# Altium schematic sheet main.SchDoc(main)
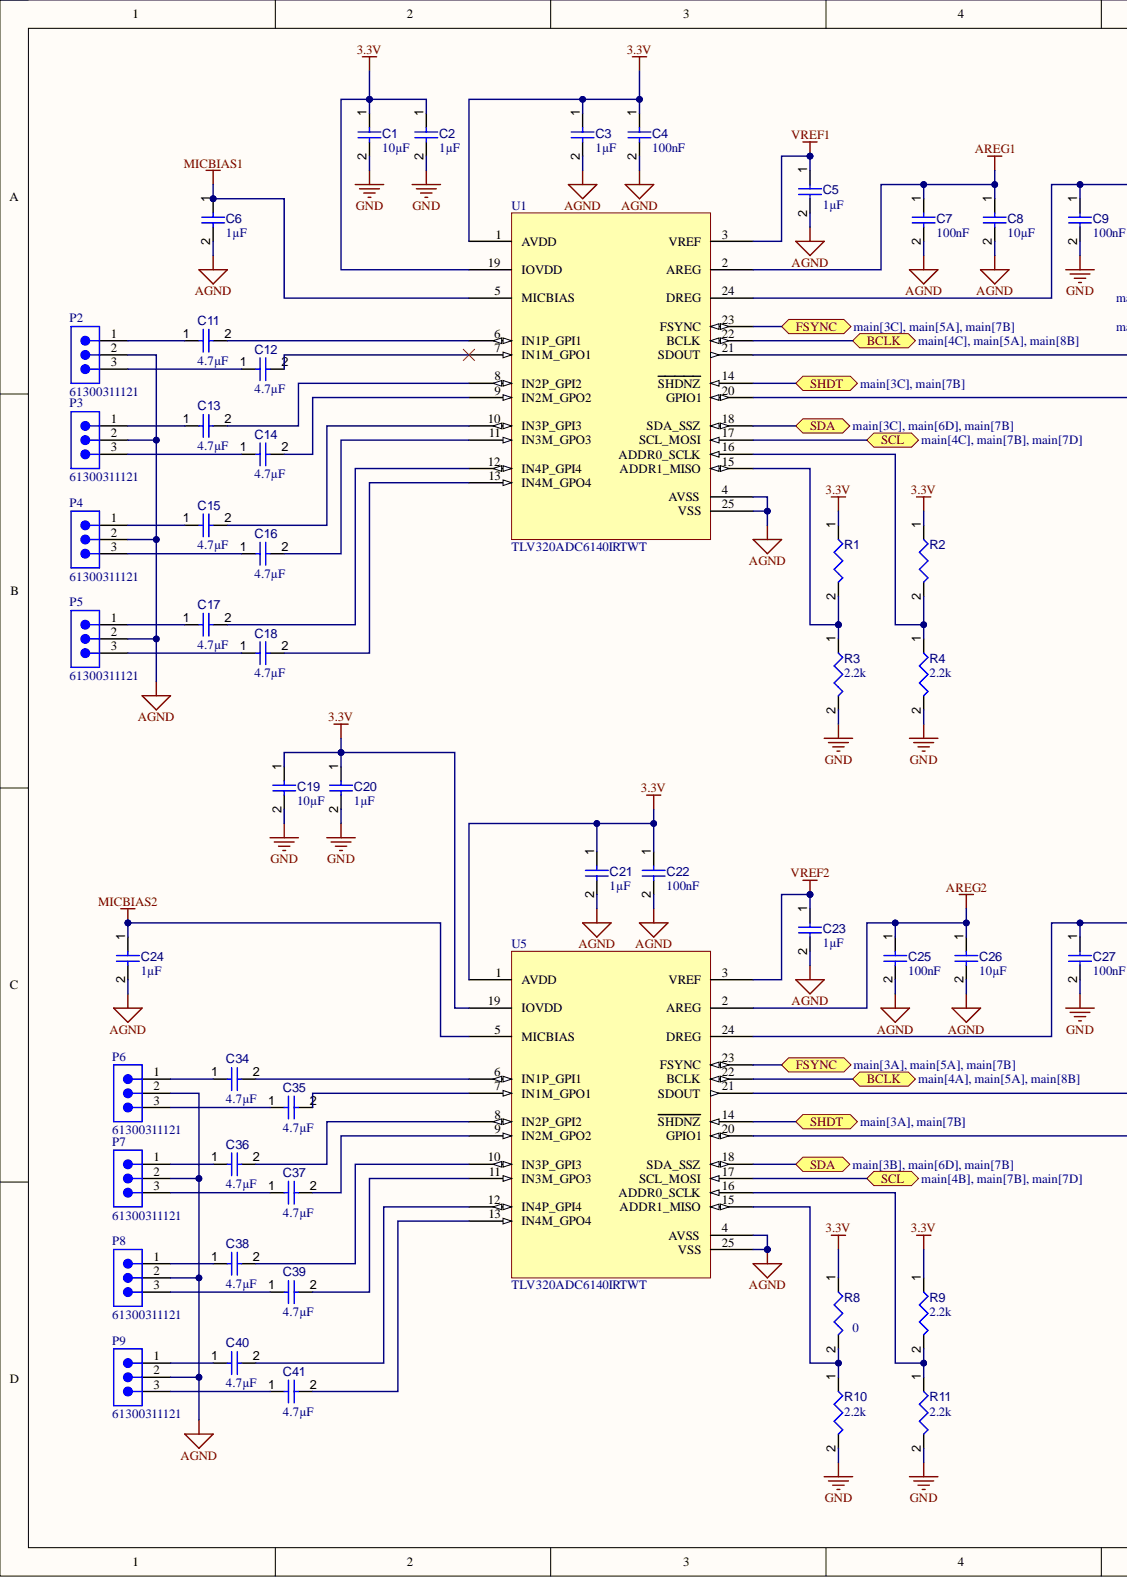
[diagram p0: main.SchDoc, left]
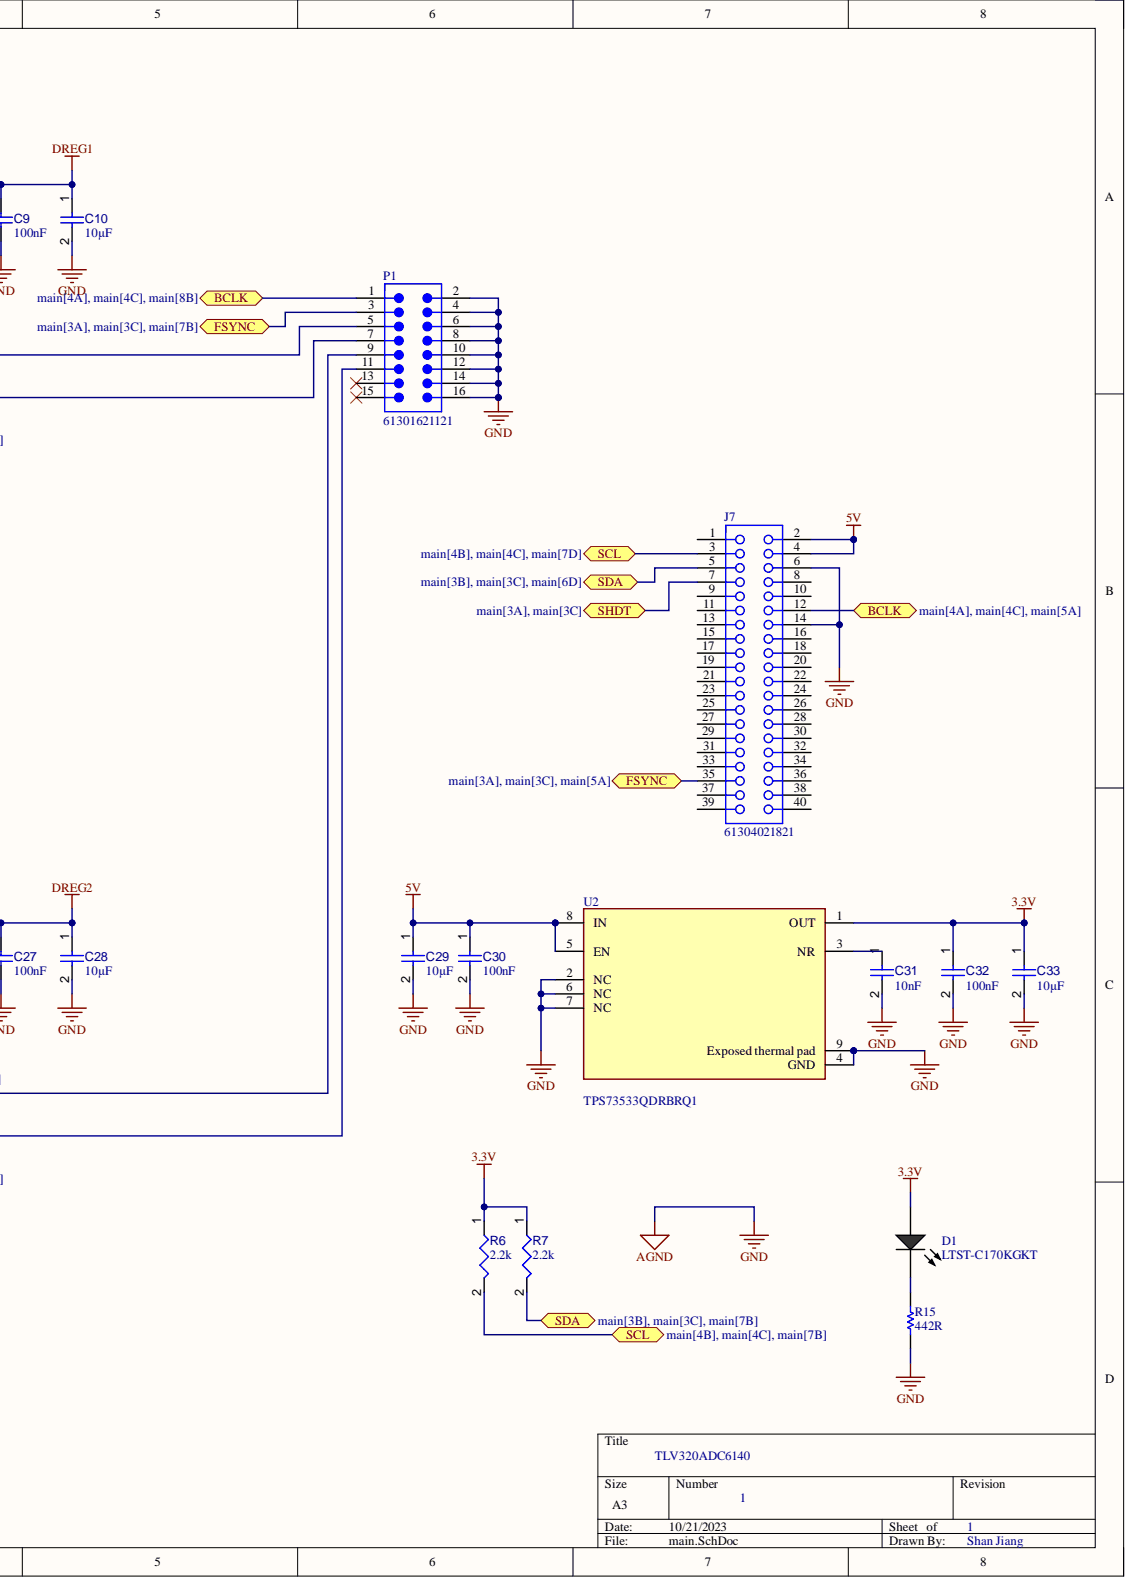
[diagram p1: main.SchDoc, right]

## Parts
C1  value 10µF  (hidden comment: GRM188R61A106ME69D)
C2  value 1µF  (hidden comment: GCM188R71C105KA64J)
C3  value 1µF  (hidden comment: GCM188R71C105KA64J)
C4  value 100nF  (hidden comment: GCM188R71C104KA37D)
C5  value 1µF  (hidden comment: GCM188R71C105KA64J)
C6  value 1µF  (hidden comment: GCM188R71C105KA64J)
C7  value 100nF  (hidden comment: GCM188R71C104KA37D)
C8  value 10µF  (hidden comment: GRM188R61A106ME69D)
C9  value 100nF  (hidden comment: GCM188R71C104KA37D)
C10  value 10µF  (hidden comment: GRM188R61A106ME69D)
C11  value 4.7µF  (hidden comment: GRM188C71A475KE11D)
C12  value 4.7µF  (hidden comment: GRM188C71A475KE11D)
C13  value 4.7µF  (hidden comment: GRM188C71A475KE11D)
C14  value 4.7µF  (hidden comment: GRM188C71A475KE11D)
C15  value 4.7µF  (hidden comment: GRM188C71A475KE11D)
C16  value 4.7µF  (hidden comment: GRM188C71A475KE11D)
C17  value 4.7µF  (hidden comment: GRM188C71A475KE11D)
C18  value 4.7µF  (hidden comment: GRM188C71A475KE11D)
C19  value 10µF  (hidden comment: GRM188R61A106ME69D)
C20  value 1µF  (hidden comment: GCM188R71C105KA64J)
C21  value 1µF  (hidden comment: GCM188R71C105KA64J)
C22  value 100nF  (hidden comment: GCM188R71C104KA37D)
C23  value 1µF  (hidden comment: GCM188R71C105KA64J)
C24  value 1µF  (hidden comment: GCM188R71C105KA64J)
C25  value 100nF  (hidden comment: GCM188R71C104KA37D)
C26  value 10µF  (hidden comment: GRM188R61A106ME69D)
C27  value 100nF  (hidden comment: GCM188R71C104KA37D)
C28  value 10µF  (hidden comment: GRM188R61A106ME69D)
C29  value 10µF  (hidden comment: GRM188R61A106ME69D)
C30  value 100nF  (hidden comment: GCM188R71C104KA37D)
C31  value 10nF  (hidden comment: GCM188R72A103KA37D)
C32  value 100nF  (hidden comment: GCM188R71C104KA37D)
C33  value 10µF  (hidden comment: GRM188R61A106ME69D)
C34  value 4.7µF  (hidden comment: GRM188C71A475KE11D)
C35  value 4.7µF  (hidden comment: GRM188C71A475KE11D)
C36  value 4.7µF  (hidden comment: GRM188C71A475KE11D)
C37  value 4.7µF  (hidden comment: GRM188C71A475KE11D)
C38  value 4.7µF  (hidden comment: GRM188C71A475KE11D)
C39  value 4.7µF  (hidden comment: GRM188C71A475KE11D)
C40  value 4.7µF  (hidden comment: GRM188C71A475KE11D)
C41  value 4.7µF  (hidden comment: GRM188C71A475KE11D)
D1  LTST-C170KGKT
J7  61304021821
P1  61301621121
P2  61300311121
P3  61300311121
P4  61300311121
P5  61300311121
P6  61300311121
P7  61300311121
P8  61300311121
P9  61300311121
R1  CRCW0603-0000Z0EA  (value not drawn)
R2  CRCW0603-0000Z0EA  (value not drawn)
R3  value 2.2k  (hidden comment: CRCW06032K20FKEA)
R4  value 2.2k  (hidden comment: CRCW06032K20FKEA)
R6  value 2.2k  (hidden comment: CRCW06032K20FKEA)
R7  value 2.2k  (hidden comment: CRCW06032K20FKEA)
R8  value 0  (hidden comment: CRCW0603-0000Z0EA)
R9  value 2.2k  (hidden comment: CRCW06032K20FKEA)
R10  value 2.2k  (hidden comment: CRCW06032K20FKEA)
R11  value 2.2k  (hidden comment: CRCW06032K20FKEA)
R15  value 442R  (hidden comment: CRCW0603442RFKEA)
U1  TLV320ADC6140IRTWT
U2  TPS73533QDRBRQ1
U5  TLV320ADC6140IRTWT

## Ports
BCLK, FSYNC, SCL, SDA, SHDT

## Nets
3.3V: C1.1 C2.1 C3.1 C4.1 C19.1 C20.1 C21.1 C22.1 C32.1 C33.1 D1.2 R1.1 R2.1 R6.1 R7.1 R8.1 R9.1 U1.1(AVDD) U1.19(IOVDD) U2.1(OUT) U5.1(AVDD) U5.19(IOVDD)
5V: C29.1 C30.1 J7.2 J7.4 U2.5(EN) U2.8(IN)
AGND: C1.2 C2.2 C3.2 C4.2 C5.2 C6.2 C7.2 C8.2 C9.2 C10.2 C19.2 C20.2 C21.2 C22.2 C23.2 C24.2 C25.2 C26.2 C27.2 C28.2 C29.2 C30.2 C31.2 C32.2 C33.2 J7.6 J7.14 P1.2 P1.4 P1.6 P1.8 P1.10 P1.12 P1.14 P1.16 P2.2 P3.2 P4.2 P5.2 P6.2 P7.2 P8.2 P9.2 R3.2 R4.2 R10.2 R11.2 R15.1 U1.4(AVSS) U1.25(VSS) U2.2(NC) U2.4(GND) U2.6(NC) U2.7(NC) U2.9(Exposed_thermal_pad) U5.4(AVSS) U5.25(VSS)
AREG1: C7.1 C8.1 U1.2(AREG)
AREG2: C25.1 C26.1 U5.2(AREG)
DREG1: C9.1 C10.1 U1.24(DREG)
DREG2: C27.1 C28.1 U5.24(DREG)
MICBIAS1: C6.1 U1.5(MICBIAS)
MICBIAS2: C24.1 U5.5(MICBIAS)
VREF1: C5.1 U1.3(VREF)
VREF2: C23.1 U5.3(VREF)
BCLK: J7.12 P1.1 U1.22(BCLK) U5.22(BCLK) ; port BCLK
FSYNC: J7.35 P1.3 U1.23(FSYNC) U5.23(FSYNC) ; port FSYNC
SCL: J7.3 R6.2 U1.17(SCL_MOSI) U5.17(SCL_MOSI) ; port SCL
SDA: J7.5 R7.2 U1.18(SDA_SSZ) U5.18(SDA_SSZ) ; port SDA
SHDT: J7.7 U1.14(SHDNZ) U5.14(SHDNZ) ; port SHDT
NetC11_1: C11.1 P2.1
NetC11_2: C11.2 U1.6(IN1P_GPI1)
NetC12_1: C12.1 P2.3
NetC12_2: C12.2 U1.7(IN1M_GPO1)
NetC13_1: C13.1 P3.1
NetC13_2: C13.2 U1.8(IN2P_GPI2)
NetC14_1: C14.1 P3.3
NetC14_2: C14.2 U1.9(IN2M_GPO2)
NetC15_1: C15.1 P4.1
NetC15_2: C15.2 U1.10(IN3P_GPI3)
NetC16_1: C16.1 P4.3
NetC16_2: C16.2 U1.11(IN3M_GPO3)
NetC17_1: C17.1 P5.1
NetC17_2: C17.2 U1.12(IN4P_GPI4)
NetC18_1: C18.1 P5.3
NetC18_2: C18.2 U1.13(IN4M_GPO4)
NetC31_1: C31.1 U2.3(NR)
NetC34_1: C34.1 P6.1
NetC34_2: C34.2 U5.6(IN1P_GPI1)
NetC35_1: C35.1 P6.3
NetC35_2: C35.2 U5.7(IN1M_GPO1)
NetC36_1: C36.1 P7.1
NetC36_2: C36.2 U5.8(IN2P_GPI2)
NetC37_1: C37.1 P7.3
NetC37_2: C37.2 U5.9(IN2M_GPO2)
NetC38_1: C38.1 P8.1
NetC38_2: C38.2 U5.10(IN3P_GPI3)
NetC39_1: C39.1 P8.3
NetC39_2: C39.2 U5.11(IN3M_GPO3)
NetC40_1: C40.1 P9.1
NetC40_2: C40.2 U5.12(IN4P_GPI4)
NetC41_1: C41.1 P9.3
NetC41_2: C41.2 U5.13(IN4M_GPO4)
NetD1_1: D1.1 R15.2
NetP1_5: P1.5 U1.21(SDOUT)
NetP1_7: P1.7 U1.20(GPIO1)
NetP1_9: P1.9 U5.21(SDOUT)
NetP1_11: P1.11 U5.20(GPIO1)
NetR1_2: R1.2 R3.1 U1.15(ADDR1_MISO)
NetR2_2: R2.2 R4.1 U1.16(ADDR0_SCLK)
NetR8_2: R8.2 R10.1 U5.15(ADDR1_MISO)
NetR9_2: R9.2 R11.1 U5.16(ADDR0_SCLK)

Not connected: J7 1 8 9 10 11 13 15 16 17 18 19 20 21 22 23 24 25 26 27 28 29 30 31 32 33 34 36 37 38 39 40; P1 13 15

## Library data of the parts
C1 C8 C10 C19 C26 C28 C29 C33 = CMP-001-00101-1: Ceramic Capacitor, Multilayer, Ceramic, 10V, 20% +Tol, 20% -Tol, X5R, 15% TC, 10uF, Surface Mount, 0603; Capacitor Type: Ceramic; Case/Package: 0603; Component Kind: Standard; LCSC PART #: C90053; Max Operating Temperature: 85°C; Min Operating Temperature: -55°C; Mounting Technology: Surface Mount; Pins: 2; RoHS Compliant: Yes; Library Name: Georgia Institute of Technology
C2 C3 C5 C6 C20 C21 C23 C24 = CMP-001-00099-2: Ceramic Capacitor, Multilayer, Ceramic, 16V, 10% +Tol, 10% -Tol, X7R, 15% TC, 1uF, Surface Mount, 0603; Capacitor Type: Ceramic; Case/Package: 0603; Component Kind: Standard; Dielectric Material: Ceramic; Height: 0.9mm; LCSC PART #: C2169902; Max Operating Temperature: 125°C; Min Operating Temperature: -55°C; Mounting Technology: Surface Mount; Pins: 2; RoHS Compliant: Yes; Library Name: Georgia Institute of Technology
C4 C7 C9 C22 C25 C27 C30 C32 = CMP-001-00100-1: Ceramic Capacitor, Multilayer, Ceramic, 16V, 10% +Tol, 10% -Tol, X7R, 15% TC, 0.1uF, Surface Mount, 0603; Capacitor Type: Ceramic; Case/Package: 0603; Component Kind: Standard; LCSC PART #: C184760; Max Operating Temperature: 125°C; Min Operating Temperature: -55°C; Mounting Technology: Surface Mount; Pins: 2; RoHS Compliant: Yes; Library Name: Georgia Institute of Technology
C11-C18 C34-C41 = CMP-001-00103-1: Ceramic Capacitor, Multilayer, Ceramic, 10V, 10% +Tol, 10% -Tol, X7S, 22% TC, 4.7uF, Surface Mount, 0603; Capacitor Type: Ceramic; Case/Package: 0603; Component Kind: Standard; LCSC PART #: C385034; Max Operating Temperature: 125°C; Min Operating Temperature: -55°C; Mounting Technology: Surface Mount; Pins: 2; RoHS Compliant: Yes; Library Name: Georgia Institute of Technology
C31 = CMP-001-00104-1: Ceramic Capacitor, Multilayer, Ceramic, 100V, 10% +Tol, 10% -Tol, X7R, 15% TC, 0.01uF, Surface Mount, 0603; Capacitor Type: Ceramic; Case/Package: 0603; Component Kind: Standard; LCSC PART #: C161232; Max Operating Temperature: 125°C; Min Operating Temperature: -55°C; Mounting Technology: Surface Mount; Pins: 2; RoHS Compliant: Yes; Library Name: Georgia Institute of Technology
D1 = CMP-007-00039-1: LED Uni-Color Green 574nm 2-Pin Chip LED T/R; Dominant Wavelength: 571nm; Forward Current: 30mA; Forward Voltage: 2V; Lens Color: Colorless; Lens Size: 1.25mm; Lens Style: Flat,Diffused,Colorless,Rectangular,Clear; Lens Transparency: Clear; Luminous Intensity: 35mcd; Number of Elements: 1; Power Dissipation: 75mW; Reverse Voltage: 5V; Test Current: 20mA; Viewing Angle: 130°; Case Code (Imperial): 0805; Case Code (Metric): 2012; Case/Package: 0805; Color: Green; Component Kind: Standard; Depth: 1.25mm; Height: 1.1mm; Illumination Color: Green; Lead Free: Lead Free; Length: 2mm; Max Operating Temperature: 85°C; Min Operating Temperature: -55°C; Mounting Technology: Surface Mount; Number of LEDs: 1; Package Quantity: 3000; Packaging: Tape and Reel; Pins: 2; Power Rating: 75mW; Radiation Hardening: No; RoHS Compliant: Yes; Series: -; Wavelength: 574nm; Width: 1.25mm; Library Name: Georgia Institute of Technology
J7 = CMP-002-00086-1: THT Vertical Socket Header WR-PHD, Pitch 2.54 mm, Dual Row, 40 pins; Gender: Female; Component Kind: Standard; Contact Material: Copper Alloy; RoHS Compliant: Yes; Library Name: Georgia Institute of Technology
P1 = CMP-002-00093-1: THT Vertical Pin Header WR-PHD, Pitch 2.54 mm, Dual Row, 16 pins; Gender: Male; Number of Contacts: 16; Pitch: 0.1inch; Color: Black; Component Kind: Standard; Contact Material: Copper; Contact Mating Length: 0.236inch; Contact Plating: Gold; Contact Type: Male Pin; Mounting Technology: Through Hole; Number of Positions: 16; Number of Rows: 2; Packaging: Bulk; Reach SVHC Compliant: Unknown; RoHS Compliant: Yes; Row Spacing: 0.1inch; Series: WR-PHD; Termination: Solder; Library Name: Georgia Institute of Technology
P2-P9 = CMP-002-00096-1: THT Vertical Pin Header WR-PHD, Pitch 2.54 mm, Single Row, 3 pins; Gender: Male; Number of Contacts: 3; Pitch: 0.1inch; Color: Black; Component Kind: Standard; Contact Material: Copper; Contact Mating Length: 0.236inch; Contact Plating: Gold; Contact Type: Male Pin; Lead Free: Lead Free; Mounting Technology: Through Hole; Number of Positions: 3; Number of Rows: 1; Packaging: Bulk; Reach SVHC Compliant: Unknown; RoHS Compliant: Yes; Series: WR-PHD; Termination: Solder; Library Name: Georgia Institute of Technology
R1 R2 R8 = CMP-009-00190-1: Resistor SMD 0 Ohm 0603 PU=5000 ST, CRCW06030000Z0EA, Vishay; Component Kind: Standard; Library Name: Georgia Institute of Technology
R3 R4 R6 R7 R9-R11 = CMP-009-00191-1: Fixed Resistor, Metal Glaze/thick Film, 0.1W, 2200ohm, 75V, 1% +/-Tol, 100ppm/Cel, Surface Mount, 0603; Power: 100mW; Case/Package: 0603; Component Kind: Standard; Max Operating Temperature: 155°C; Min Operating Temperature: -55°C; Mounting Technology: Surface Mount; Pins: 2; RoHS Compliant: Yes; Tolerance: 1%; Library Name: Georgia Institute of Technology
R15 = CMP-009-00189-1: Res Thick Film 0603 442 Ohm 1% 1/10W ±100ppm/°C Molded SMD SMD Paper T/R; Max Power Dissipation: 100mW; Voltage Rating: 75V; Case Code (Imperial): 0603; Case Code (Metric): 1608; Case/Package: 0603; Component Kind: Standard; Construction: Rectangular; Contact Plating: Tin; Depth: 0.85mm; Features: Automotive AEC-Q200; Height: 0.45mm; Lead Free: Lead Free; Length: 1.55mm; Max Operating Temperature: 155°C; Military Standard: Not; Min Operating Temperature: -55°C; Number of Terminations: 2; Package Quantity: 5000; Packaging: Tape and Reel; Pins: 2; Power Rating: 100mW; Radiation Hardening: No; Reach SVHC Compliant: Unknown; Resistance Tolerance: 1%; Resistor Type: Thick Film; RoHS Compliant: Yes; Series: CRCW; Technology: THICK FILM; Temperature Coefficient: 100ppm/°C; Termination: SMD/SMT; Tolerance: 1%; Voltage Rating (DC): 75V; Weight: 7.1E-05oz; Width: 0.85mm; Library Name: Georgia Institute of Technology
U1 U5 = CMP-020-00024-4: Quad-channel 768-kHz Burr-Brown™ audio analog-to-digital converter (ADC) with 122-dB SNR 24-WQFN -40 to 125; Interface: I2C,SPI; Number of Channels: 4; Sampling Rate: 768000S/s; Supply Type: Analog,Digital; Component Kind: Standard; LCSC PART #: C1852023; Max Operating Temperature: 125°C; Min Operating Temperature: -40°C; Mounting Technology: Surface Mount; RoHS Compliant: Yes; Series: -; Library Name: Georgia Institute of Technology
U2 = CMP-019-00049-3: LDO Regulator Pos 3.3V 0.5A Automotive 8-Pin VSON EP T/R; Dropout Voltage: 280mV; Max Supply Voltage: 6.5V; Min Current Limit: 800mA; Number of Outputs: 1; Output Configuration: Positive; Output Current: 500mA; Output Type: Fixed; Power Supply Rejection Ratio (PSRR): 21dB; Quiescent Current: 65uA; Case/Package: SON; Component Kind: Standard; LCSC PART #: C2867192; Lead Free: Lead Free; Max Operating Temperature: 125°C; Min Operating Temperature: -40°C; Min Output Voltage: 3.3V; Mounting Technology: Surface Mount; Number of Regulators: 1; Output Voltage: 3.3V; Package Quantity: 3000; Packaging: Tape and Reel; Pins: 8; RoHS Compliant: Yes; Series: Automotive, AEC-Q100; Weight: 0.002677oz; Library Name: Georgia Institute of Technology
